# Altium schematic sheet Nav_Retro_IO.SchDoc
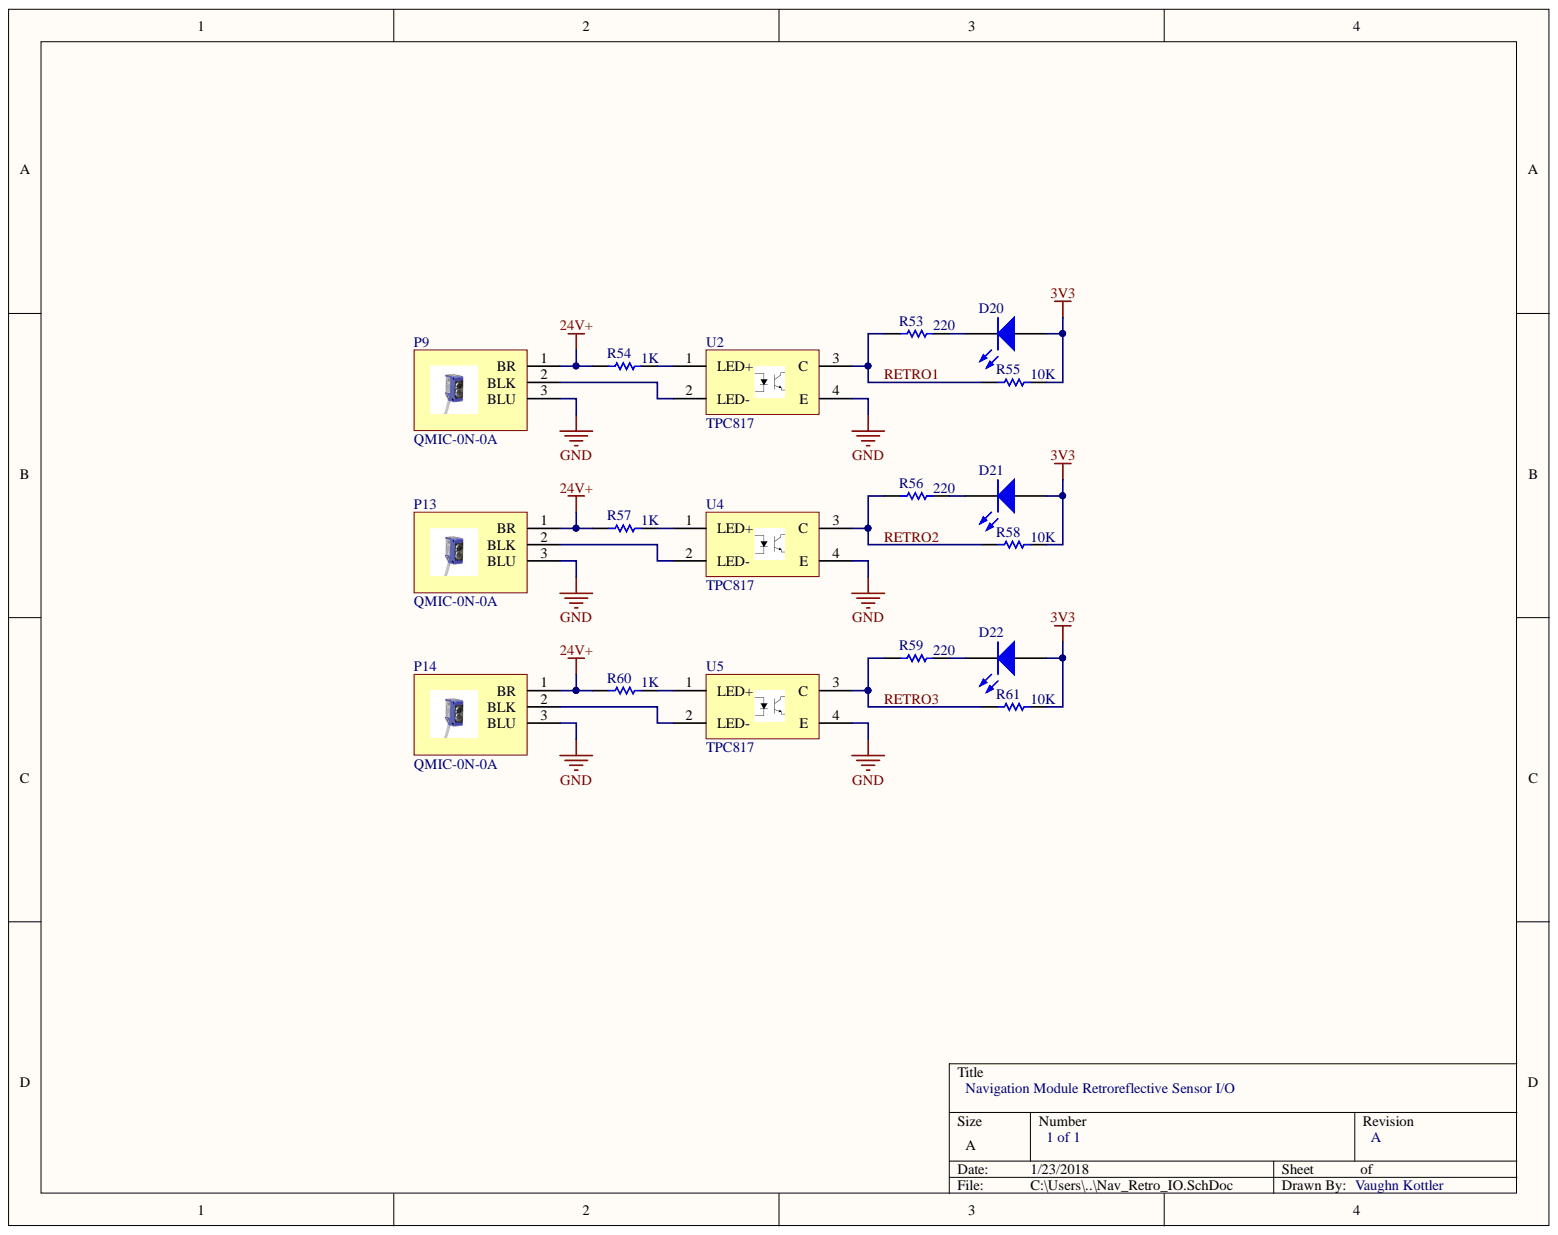
[diagram p0: Nav_Retro_IO.SchDoc]

## Parts
D20  LED2  [3.2X1.6X1.1]  (value not drawn)
D21  LED2  [3.2X1.6X1.1]  (value not drawn)
D22  LED2  [3.2X1.6X1.1]  (value not drawn)
P9  QMIC-0N-0A  [3POS TERM BLOCK]
P13  QMIC-0N-0A  [3POS TERM BLOCK]
P14  QMIC-0N-0A  [3POS TERM BLOCK]
R53  value 220  [6-0805_M]  (hidden comment: Res1)
R54  value 1K  [6-0805_M]  (hidden comment: Res1)
R55  value 10K  [6-0805_M]  (hidden comment: Res1)
R56  value 220  [6-0805_M]  (hidden comment: Res1)
R57  value 1K  [6-0805_M]  (hidden comment: Res1)
R58  value 10K  [6-0805_M]  (hidden comment: Res1)
R59  value 220  [6-0805_M]  (hidden comment: Res1)
R60  value 1K  [6-0805_M]  (hidden comment: Res1)
R61  value 10K  [6-0805_M]  (hidden comment: Res1)
U2  TPC817  [4-SOP]
U4  TPC817  [4-SOP]
U5  TPC817  [4-SOP]

## Nets
3V3: D20.1 D21.1 D22.1 R55.2 R58.2 R61.2
24V+: P9.1 P13.1 P14.1 R54.1 R57.1 R60.1
GND: P9.3 P13.3 P14.3 U2.4(E) U4.4(E) U5.4(E)
RETRO1: R53.1 R55.1 U2.3(C)
RETRO2: R56.1 R58.1 U4.3(C)
RETRO3: R59.1 R61.1 U5.3(C)
NetD20_2: D20.2 R53.2
NetD21_2: D21.2 R56.2
NetD22_2: D22.2 R59.2
NetP9_2: P9.2 U2.2(LED-)
NetP13_2: P13.2 U4.2(LED-)
NetP14_2: P14.2 U5.2(LED-)
NetR54_2: R54.2 U2.1(LED+)
NetR57_2: R57.2 U4.1(LED+)
NetR60_2: R60.2 U5.1(LED+)

## Library data of the parts
D20-D22 = LED2: Typical RED, GREEN, YELLOW, AMBER GaAs LED; Component Kind: Standard; LatestRevisionDate: 17-Jul-2002; LatestRevisionNote: Re-released for DXP Platform.; Library Name: Miscellaneous Devices.IntLib; PackageDescription: SM LED; 2 Flat Leads; PackageReference: 3.2X1.6X1.1; PackageVersion: Feb-2002; Published: 8-Jun-2000; Simulation: LED2; Publisher: Altium Limited
P9 P13 P14 = QMIC-0N-0A: Retroreflective Sensor; Component Kind: Standard; ComponentLink1Description: Sensor; ComponentLink1URL: https://www.automationdirect.com/adc/Shopping/Catalog/Sensors_-z-_Encoders/Photoelectric_Sensors/DC_Rectangular/Retroreflective_(QM-z-CX-z-FE_Series)/QMIC-0N-0A; ComponentLink2Description: Datasheet; ComponentLink2URL: https://cdn.automationdirect.com/static/specs/peminirectqm.pdf; Library Name: connector.SchLib
R53-R61 = Res1: Resistor; Component Kind: Standard; LatestRevisionDate: 17-Jul-2002; LatestRevisionNote: Re-released for DXP Platform.; Library Name: Miscellaneous Devices.IntLib; PackageDescription: Axial Device, Thru-Hole; 2 Leads; 0.3 in Pin Spacing; PackageReference: AXIAL-0.3; Published: 8-Jun-2000; Signal Integrity: Res; Simulation: RESISTOR; Publisher: Altium Limited
U2 U4 U5 = TPC817: Opto-Coupler; Component Kind: Standard; ComponentLink1Description: Digi-Key; ComponentLink1URL: https://www.digikey.com/product-detail/en/taiwan-semiconductor-corporation/TPC817S1D-RAG/TPC817S1D-RAGCT-ND/7359685; ComponentLink2Description: Datasheet; ComponentLink2URL: http://www.taiwansemi.com/products/datasheet/TPC817%20SERIES_C1612.pdf; Library Name: ic.SchLib
